# Altium schematic sheet IOINOUT.SchDoc(IOINOUT)
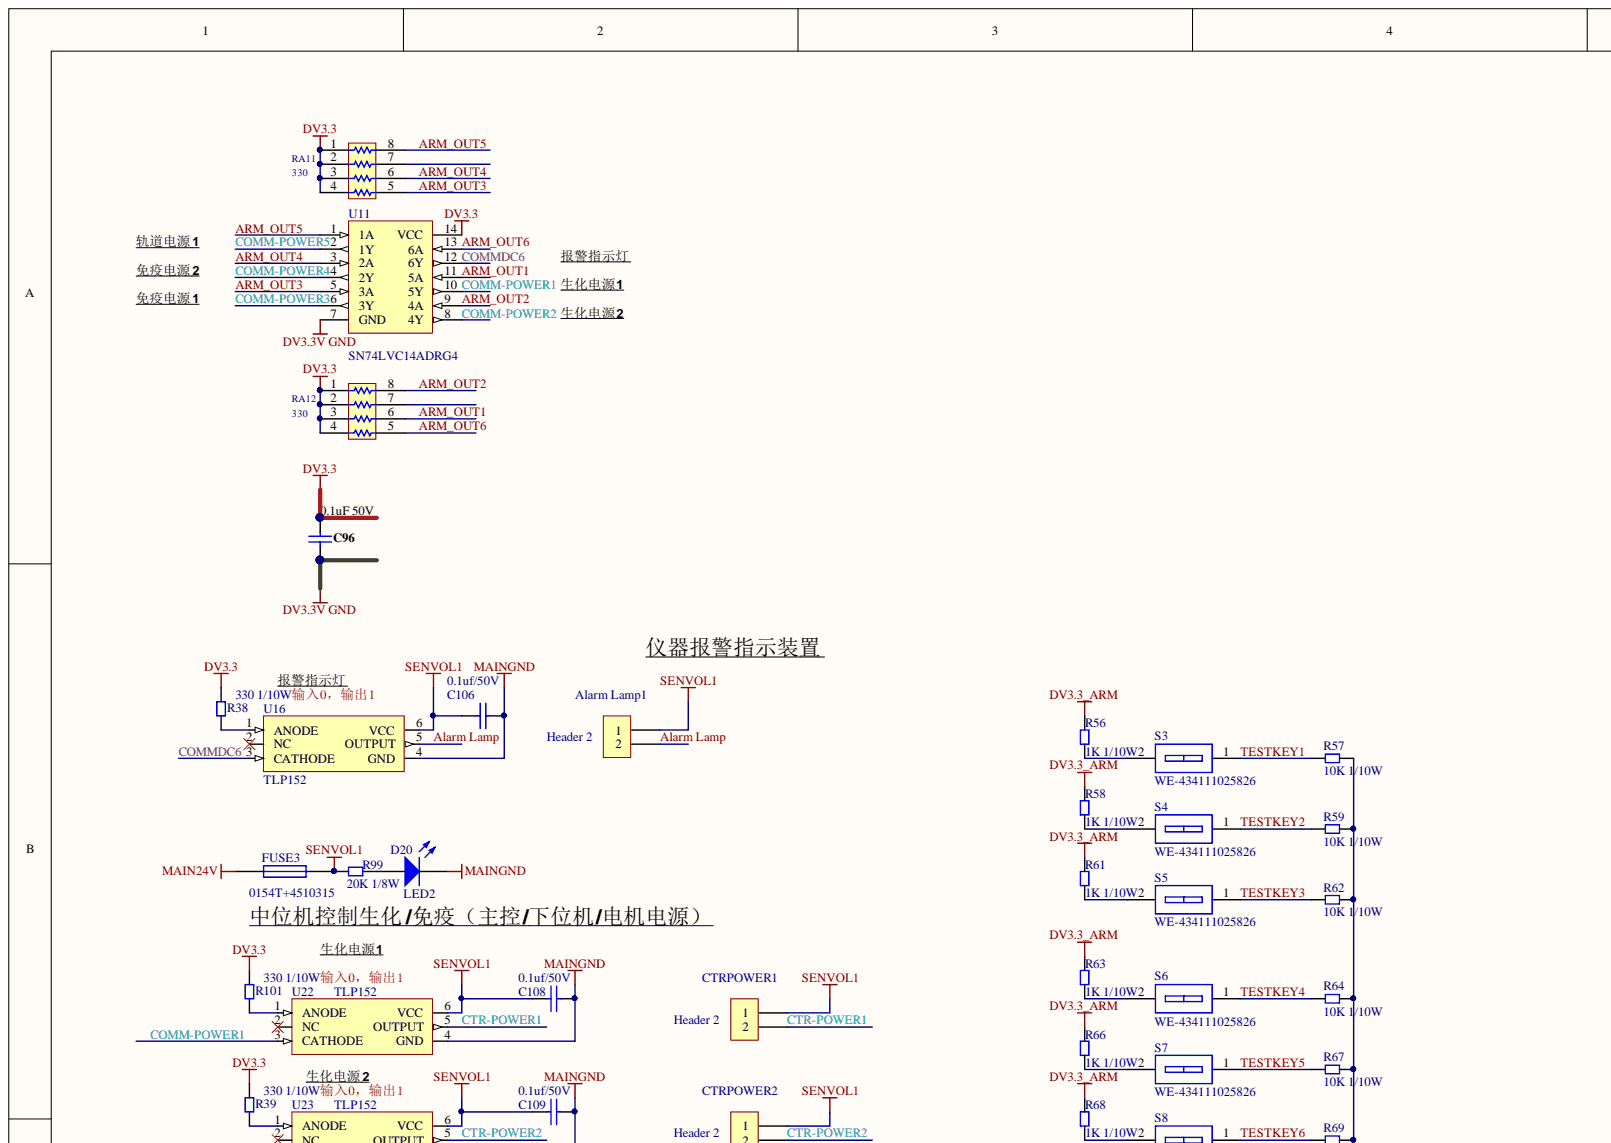
[diagram p0: IOINOUT.SchDoc, top left]
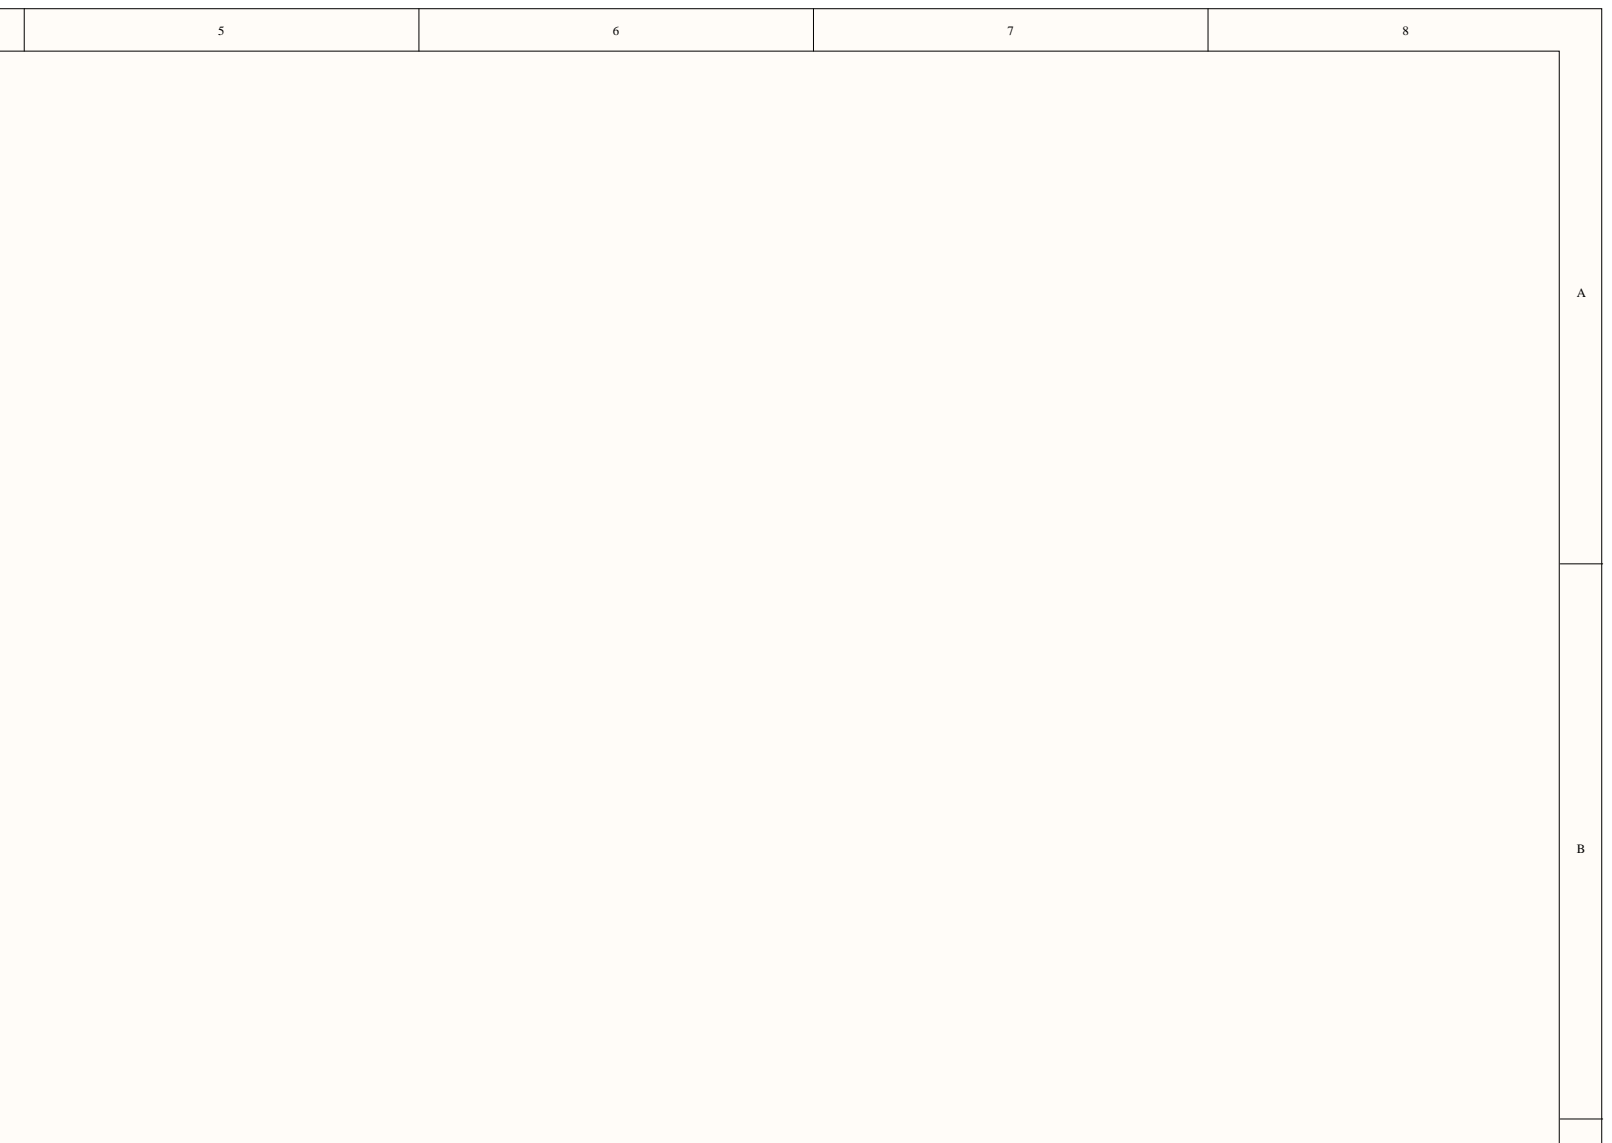
[diagram p1: IOINOUT.SchDoc, top right]
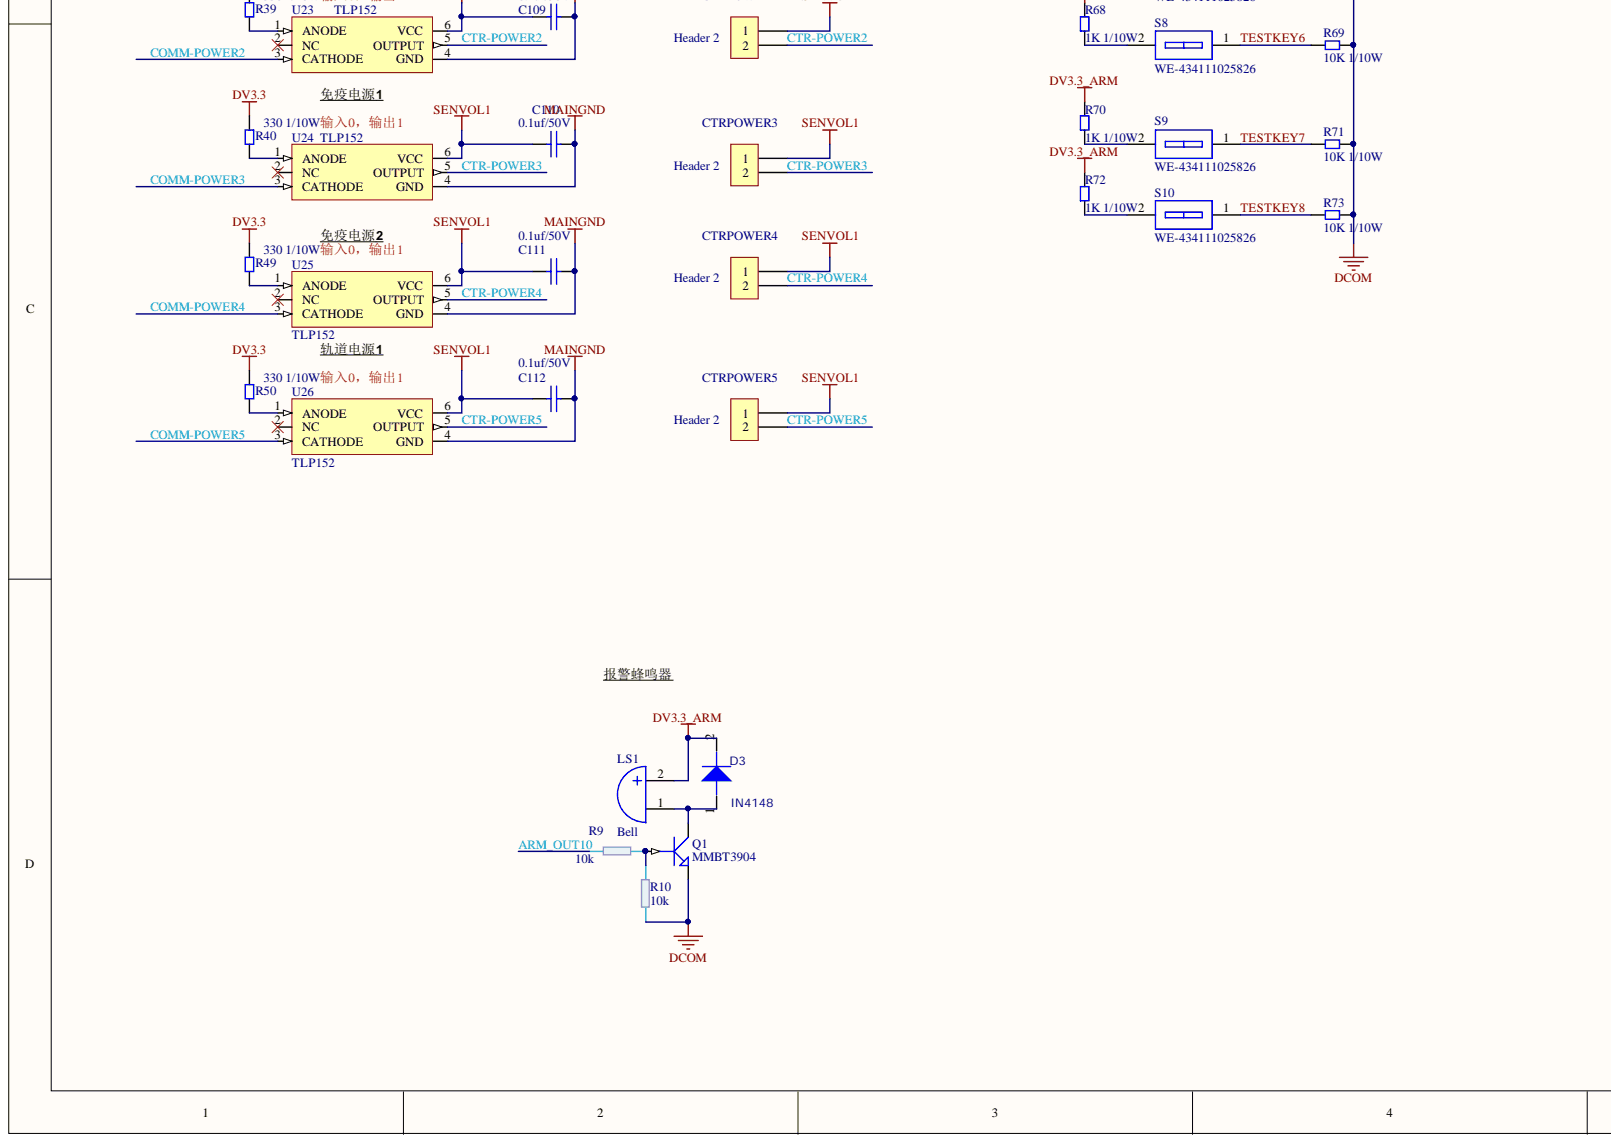
[diagram p2: IOINOUT.SchDoc, bottom left]
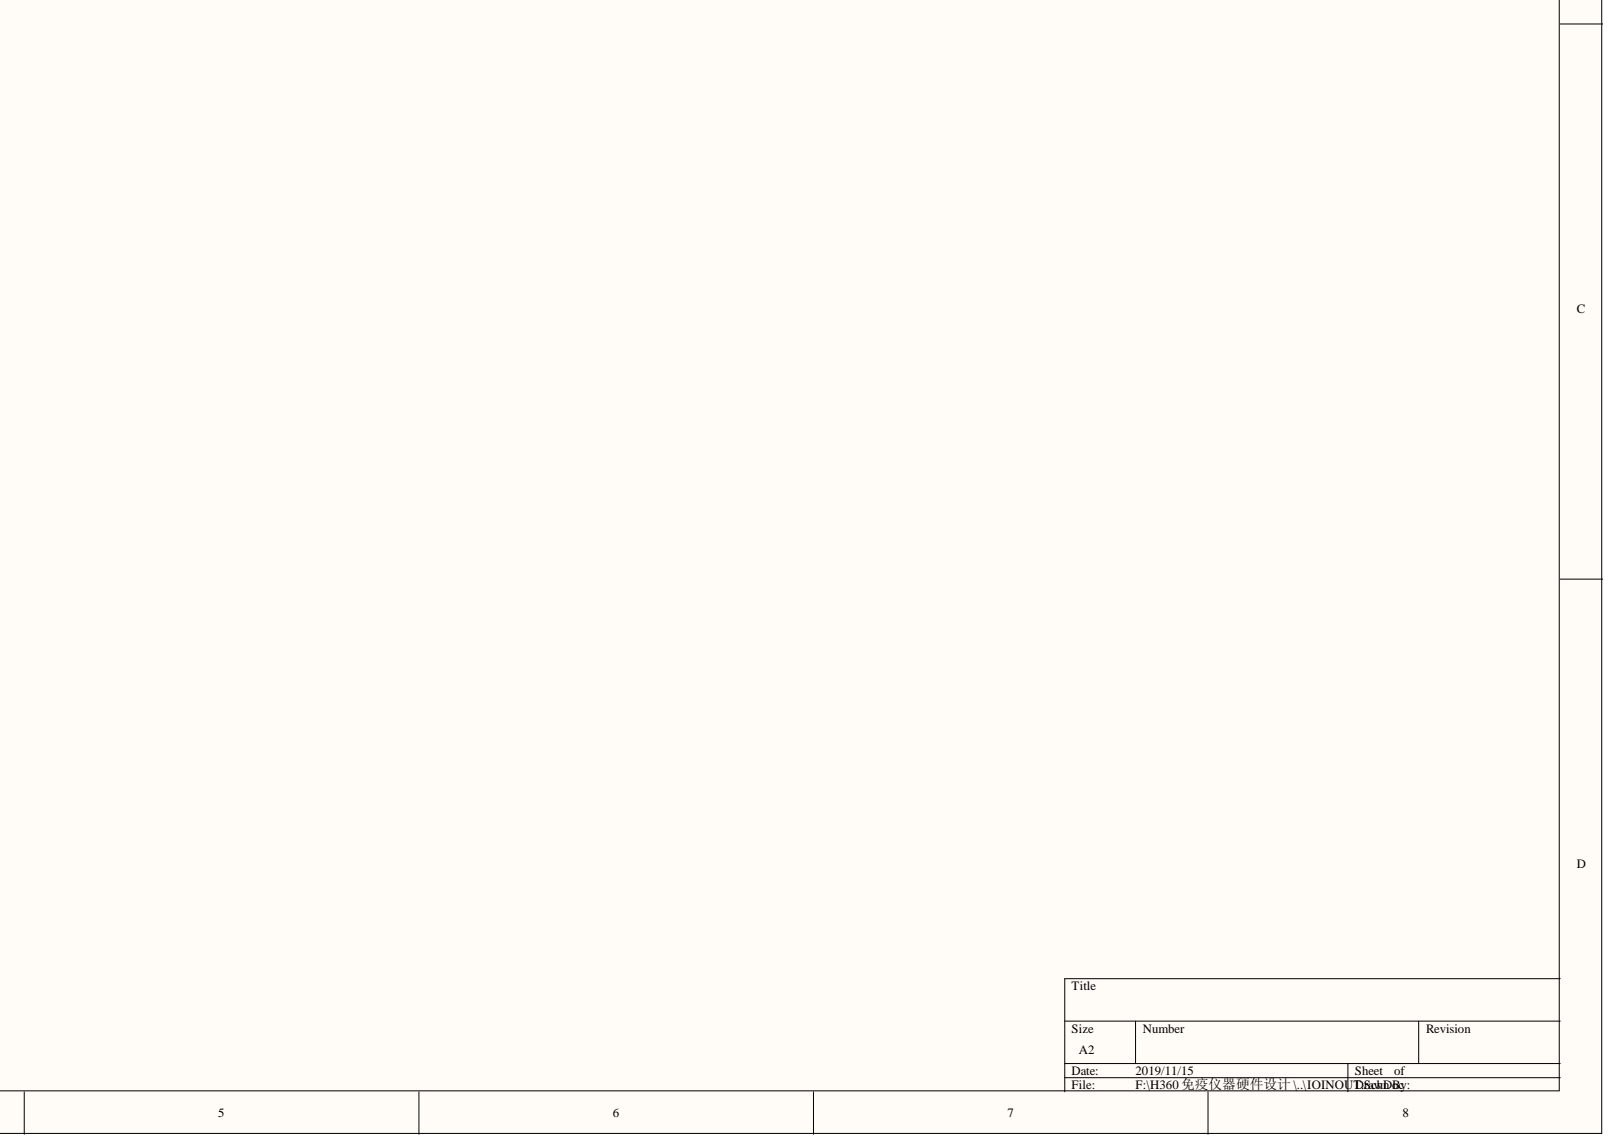
[diagram p3: IOINOUT.SchDoc, bottom right]

## Parts
Alarm_Lamp1  Header 2  [WR-MPC4-4.2MM-2PIN_64900229522]
C96  0.1uF 50V  [C0603_104/50V]
C106  0.1uf/50V  [C0805_104/50V]
C108  0.1uf/50V  [C0805_104/50V]
C109  0.1uf/50V  [C0805_104/50V]
C110  0.1uf/50V  [C0805_104/50V]
C111  0.1uf/50V  [C0805_104/50V]
C112  0.1uf/50V  [C0805_104/50V]
CTRPOWER1  Header 2  [WR-MPC4-4.2MM-2PIN_64900229522]
CTRPOWER2  Header 2  [WR-MPC4-4.2MM-2PIN_64900229522]
CTRPOWER3  Header 2  [WR-MPC4-4.2MM-2PIN_64900229522]
CTRPOWER4  Header 2  [WR-MPC4-4.2MM-2PIN_64900229522]
CTRPOWER5  Header 2  [WR-MPC4-4.2MM-2PIN_64900229522]
D3  IN4148  [SOD123_IN4148]
D20  LED2  [LED_0805]
FUSE3  0154T+4510315  [FUSE2410ZUO]
LS1  Bell  [BELL]
Q1  MMBT3904  [SOT-23_MMBT3904]
R9  10k  [RES0603_104]
R10  10k  [RES0603_104]
R38  330 1/10W  [RES0603]
R39  330 1/10W  [RES0603]
R40  330 1/10W  [RES0603]
R49  330 1/10W  [RES0603]
R50  330 1/10W  [RES0603]
R56  1K 1/10W  [res0603_103]
R57  10K 1/10W  [RES0603]
R58  1K 1/10W  [res0603_103]
R59  10K 1/10W  [RES0603]
R61  1K 1/10W  [res0603_103]
R62  10K 1/10W  [RES0603]
R63  1K 1/10W  [res0603_103]
R64  10K 1/10W  [RES0603]
R66  1K 1/10W  [res0603_103]
R67  10K 1/10W  [RES0603]
R68  1K 1/10W  [res0603_103]
R69  10K 1/10W  [RES0603]
R70  1K 1/10W  [res0603_103]
R71  10K 1/10W  [RES0603]
R72  1K 1/10W  [res0603_103]
R73  10K 1/10W  [RES0603]
R99  20K 1/8W  [RES0805_203]
R101  330 1/10W  [RES0603]
RA11  330  [ra_yc164_331]
RA12  330  [ra_yc164_331]
S3  WE-434111025826  [KEY735SO]
S4  WE-434111025826  [KEY735SO]
S5  WE-434111025826  [KEY735SO]
S6  WE-434111025826  [KEY735SO]
S7  WE-434111025826  [KEY735SO]
S8  WE-434111025826  [KEY735SO]
S9  WE-434111025826  [KEY735SO]
S10  WE-434111025826  [KEY735SO]
U11  SN74LVC14ADRG4  [SOIC-14-SN74LVC07AD]
U16  TLP152  [SO6_TLP152]
U22  TLP152  [SO6_TLP152]
U23  TLP152  [SO6_TLP152]
U24  TLP152  [SO6_TLP152]
U25  TLP152  [SO6_TLP152]
U26  TLP152  [SO6_TLP152]

## Nets
DCOM: Q1.2 R10.1 R57.1 R59.1 R62.1 R64.1 R67.1 R69.1 R71.1 R73.1
DV3.3: C96.1 R38.1 R39.1 R40.1 R49.1 R50.1 R101.1 RA11.1 RA11.2 RA11.3 RA11.4 RA12.1 RA12.2 RA12.3 RA12.4 U11.14(VCC)
DV3.3_ARM: D3.2 LS1.2 R56.2 R58.2 R61.2 R63.2 R66.2 R68.2 R70.2 R72.2
DV3.3V GND: C96.2 U11.7(GND)
MAIN24V: FUSE3.2
MAINGND: C106.1 C108.1 C109.1 C110.1 C111.1 C112.1 D20.2 U16.4(GND) U22.4(GND) U23.4(GND) U24.4(GND) U25.4(GND) U26.4(GND)
SENVOL1: Alarm_Lamp1.1 C106.2 C108.2 C109.2 C110.2 C111.2 C112.2 CTRPOWER1.1 CTRPOWER2.1 CTRPOWER3.1 CTRPOWER4.1 CTRPOWER5.1 FUSE3.1 R99.2 U16.6(VCC) U22.6(VCC) U23.6(VCC) U24.6(VCC) U25.6(VCC) U26.6(VCC)
ALARM LAMP: Alarm_Lamp1.2 U16.5(OUTPUT)
ARM_OUT1: RA12.6 U11.11(5A)
ARM_OUT2: RA12.8 U11.9(4A)
ARM_OUT3: RA11.5 U11.5(3A)
ARM_OUT4: RA11.6 U11.3(2A)
ARM_OUT5: RA11.8 U11.1(1A)
ARM_OUT6: RA12.5 U11.13(6A)
ARM_OUT10: R9.1
COMM-POWER1: U11.10(5Y) U22.3(CATHODE)
COMM-POWER2: U11.8(4Y) U23.3(CATHODE)
COMM-POWER3: U11.6(3Y) U24.3(CATHODE)
COMM-POWER4: U11.4(2Y) U25.3(CATHODE)
COMM-POWER5: U11.2(1Y) U26.3(CATHODE)
COMMDC6: U11.12(6Y) U16.3(CATHODE)
CTR-POWER1: CTRPOWER1.2 U22.5(OUTPUT)
CTR-POWER2: CTRPOWER2.2 U23.5(OUTPUT)
CTR-POWER3: CTRPOWER3.2 U24.5(OUTPUT)
CTR-POWER4: CTRPOWER4.2 U25.5(OUTPUT)
CTR-POWER5: CTRPOWER5.2 U26.5(OUTPUT)
TESTKEY1: R57.2 S3.1
TESTKEY2: R59.2 S4.1
TESTKEY3: R62.2 S5.1
TESTKEY4: R64.2 S6.1
TESTKEY5: R67.2 S7.1
TESTKEY6: R69.2 S8.1
TESTKEY7: R71.2 S9.1
TESTKEY8: R73.2 S10.1
NetD3_1: D3.1 LS1.1 Q1.3
NetD20_1: D20.1 R99.1
NetQ1_1: Q1.1 R9.2 R10.2
NetR38_2: R38.2 U16.1(ANODE)
NetR39_2: R39.2 U23.1(ANODE)
NetR40_2: R40.2 U24.1(ANODE)
NetR49_2: R49.2 U25.1(ANODE)
NetR50_2: R50.2 U26.1(ANODE)
NetR56_1: R56.1 S3.2
NetR58_1: R58.1 S4.2
NetR61_1: R61.1 S5.2
NetR63_1: R63.1 S6.2
NetR66_1: R66.1 S7.2
NetR68_1: R68.1 S8.2
NetR70_1: R70.1 S9.2
NetR72_1: R72.1 S10.2
NetR101_2: R101.2 U22.1(ANODE)

Not connected: RA11 7; RA12 7; U16 2(NC); U22 2(NC); U23 2(NC); U24 2(NC); U25 2(NC); U26 2(NC)

## Library data of the parts
Alarm_Lamp1 CTRPOWER1-CTRPOWER5 = Header 2: Header, 2-Pin; Component Kind: Standard; Library Name: DC out42_1.SCHLIB
C96 = D-101-0080: SAMSUNG  MULTILAYER  CERAMIC 5%; Dielectric: X7R; Polarity: Non-Polarized; Rated Voltage: 16 V; Tolerance: 10 %; Capacitance: 0.1 uF; Category: Capacitor; Component Kind: Standard; Library Name: AltiumDesignLibrary.DesignLib; Mounting Technology: Surface Mount; Status: Production; Technology: Ceramic
C106 C108-C112 = CAP: SAMSUNG MUL Ceramiac  5%; Component Kind: Standard; Library Name: 2018.328PCBlib
D3 = IN4148: PCB DECAL: DIODE_SOP; Component Kind: Standard; Library Name: F407_MB.SCHLIB; VALUE: IN4148
D20 = LED2: Typical GREEN, AMBER GaAs LED; Component Kind: Standard; LatestRevisionDate: 17-Jul-2002; LatestRevisionNote: Re-released for DXP Platform.; Library Name: Miscellaneous Devices.IntLib; PackageDescription: SM LED; 2 Flat Leads; PackageReference: 3.2X1.6X1.1; PackageVersion: Feb-2002; Published: 8-Jun-2000; Simulation: LED2; Publisher: Altium Limited
FUSE3 = FUSE1: littelfuse  Surface Mount Fuses  154T+04510315; Component Kind: Standard
LS1 = Bell: Electrical Bell; Component Kind: Standard; LatestRevisionDate: 17-Jul-2002; LatestRevisionNote: Re-released for DXP Platform.; Library Name: 3DGeneral.SCHLIB; Published: 24-Mar-1999; Publisher: Altium Limited
Q1 = NPN1: NPN Transistor; Component Kind: Standard
R9 R10 = Res2: YAGEO Chip Res 1/10W 1%; Component Kind: Standard; LatestRevisionDate: 17-Jul-2002; LatestRevisionNote: Re-released for DXP Platform.; Library Name: 3DGeneral.SCHLIB; PackageReference: AXIAL-0.4; Published: 8-Jun-2000; Signal Integrity: Res; Simulation: RESISTOR; Publisher: Altium Limited
R38-R40 R49 R50 R56-R59 R61-R64 R66-R73 R101 = RES2: YAGEO Chip Res 1/10W 1%; Component Kind: Standard
  R38-R40 R49 R50 R101: Library Name: 2018.328PCBlib
R99 = RES2: Component Kind: Standard; Library Name: 2017.4.24MainctrlBoard.PcbLib
RA11 RA12 = MNR34: 3216x4 Resistor Array; AEMS Code: 1300330; Component Kind: Standard; Library Name: 2015.10.1.SCHLIB
S3-S10 = SW DIP-2: WS-TASV SMD Tact Switch; Component Kind: Standard; Library Name: 2017.4.24 MainBoard.SchLib
U11 = 7414: HEX SCHMITT-TRIGGER INVERTERS; Component Kind: Standard; Library Name: 2015.10.1.SCHLIB
U16 U22-U26 = TLP701: Photocouplers GaA-As Infrared LED and Photo IC; Component Kind: Standard
